# Altium schematic sheet NTC Sensors.SchDoc("NTC Sensors")
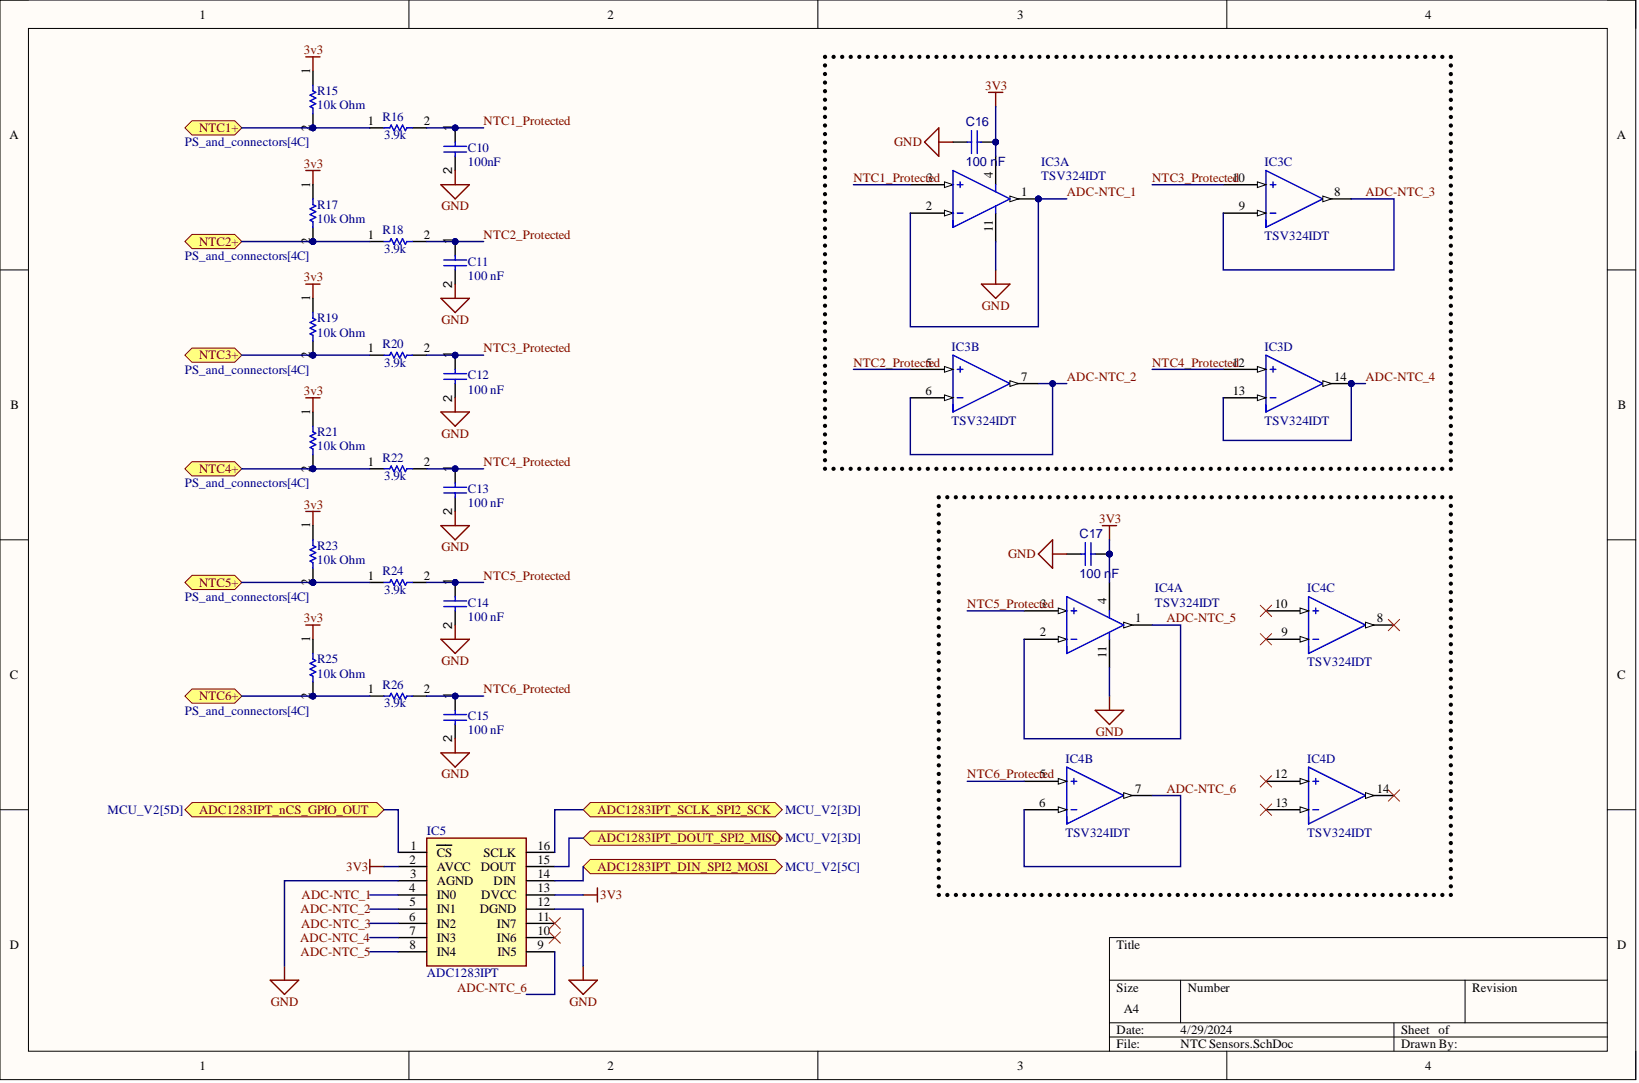
[diagram p0: NTC Sensors.SchDoc]

## Parts
C10  100nF
C11  100 nF
C12  100 nF
C13  100 nF
C14  100 nF
C15  100 nF
C16  100 nF
C17  100 nF
IC3  TSV324IDT  (parts A,B,C,D)
IC4  TSV324IDT  (parts A,B,C,D)
IC5  ADC1283IPT
R15  10k Ohm
R16  3.9k
R17  10k Ohm
R18  3.9k
R19  10k Ohm
R20  3.9k
R21  10k Ohm
R22  3.9k
R23  10k Ohm
R24  3.9k
R25  10k Ohm
R26  3.9k

## Ports
ADC1283IPT_DIN_SPI2_MOSI, ADC1283IPT_DOUT_SPI2_MISO, ADC1283IPT_nCS_GPIO_OUT, ADC1283IPT_SCLK_SPI2_SCK, NTC1+, NTC2+, NTC3+, NTC4+, NTC5+, NTC6+

## Nets
3v3: C16.1 C17.1 IC3.4 IC4.4 IC5.2(AVCC) IC5.13(DVCC) R15.1 R17.1 R19.1 R21.1 R23.1 R25.1
GND: C10.2 C11.2 C12.2 C13.2 C14.2 C15.2 C16.2 C17.2 IC3.11 IC4.11 IC5.3(AGND) IC5.12(DGND)
ADC1283IPT_DIN_SPI2_MOSI: IC5.14(DIN) ; port ADC1283IPT_DIN_SPI2_MOSI
ADC1283IPT_DOUT_SPI2_MISO: IC5.15(DOUT) ; port ADC1283IPT_DOUT_SPI2_MISO
ADC1283IPT_nCS_GPIO_OUT: IC5.1(CS) ; port ADC1283IPT_nCS_GPIO_OUT
ADC1283IPT_SCLK_SPI2_SCK: IC5.16(SCLK) ; port ADC1283IPT_SCLK_SPI2_SCK
ADC-NTC_1: IC3.1 IC3.2 IC5.4(IN0)
ADC-NTC_2: IC3.6 IC3.7 IC5.5(IN1)
ADC-NTC_3: IC3.8 IC3.9 IC5.6(IN2)
ADC-NTC_4: IC3.13 IC3.14 IC5.7(IN3)
ADC-NTC_5: IC4.1 IC4.2 IC5.8(IN4)
ADC-NTC_6: IC4.6 IC4.7 IC5.9(IN5)
NTC1+: R15.2 R16.1 ; port NTC1+
NTC1_Protected: C10.1 IC3.3 R16.2
NTC2+: R17.2 R18.1 ; port NTC2+
NTC2_Protected: C11.1 IC3.5 R18.2
NTC3+: R19.2 R20.1 ; port NTC3+
NTC3_Protected: C12.1 IC3.10 R20.2
NTC4+: R21.2 R22.1 ; port NTC4+
NTC4_Protected: C13.1 IC3.12 R22.2
NTC5+: R23.2 R24.1 ; port NTC5+
NTC5_Protected: C14.1 IC4.3 R24.2
NTC6+: R25.2 R26.1 ; port NTC6+
NTC6_Protected: C15.1 IC4.5 R26.2

Not connected: IC4 8 9 10 12 13 14; IC5 10(IN6) 11(IN7)

## Library data of the parts
C10-C15 = CMP-00009-1: VJ0805Y104MXATW1BC; Component Kind: Standard; Library Name: EMEA- Squadra Corse PoliTO-  Politecnico di Torino
C16 C17 = CMP-001-00085-5: VJ0805Y104MXATW1BC; Tech: SMD; Component Kind: Standard; ComponentLink1Description: Website; Package: 0805; Library Name: EMEA- Squadra Corse PoliTO-  Politecnico di Torino
IC3 IC4 = CMP-007-000004-4: Manufacturer_Name: STMicroelectronics; Arrow Part Number: TSV324IDT; Arrow Price/Stock: https://www.arrow.com/en/products/tsv324idt/stmicroelectronics; Component Kind: Standard; Datasheet Link: http://www.st.com/st-web-ui/static/active/en/resource/technical/document/datasheet/CD00063292.pdf; Description: TSV324IDT; Design Item ID: TSV324IDT; Height: 1.75mm; Manufacturer_Part_Number: TSV324IDT; Mouser Part Number: 511-TSV324IDT; Mouser Price/Stock: https://www.mouser.com/Search/Refine.aspx?Keyword=511-TSV324IDT; Source: SamacSys.SchLib; Library Name: EMEA- Squadra Corse PoliTO-  Politecnico di Torino
IC5 = CMP-007-000007-2: 8-Channel; Manufacturer_Name: STMicroelectronics; Component Kind: Standard; Datasheet Link: https://www.st.com/resource/en/datasheet/adc1283.pdf; Design Item ID: ADC1283IPT; Height: 1.2mm; Manufacturer_Part_Number: ADC1283IPT; Source: SamacSys.SchLib; Library Name: EMEA- Squadra Corse PoliTO-  Politecnico di Torino
R15 R17 R19 R21 R23 R25 = CMP-009-00179-5: RCS060310K0FKEA; Tech: SMD; Component Kind: Standard; ComponentLink1Description: Website; Package: 0603; Library Name: EMEA- Squadra Corse PoliTO-  Politecnico di Torino
R16 R18 R20 R22 R24 R26 = CMP-00012-1: CRCW08053K90FKEAHP; Component Kind: Standard; Library Name: EMEA- Squadra Corse PoliTO-  Politecnico di Torino
